# Altium schematic sheet FPGA_Config.SchDoc
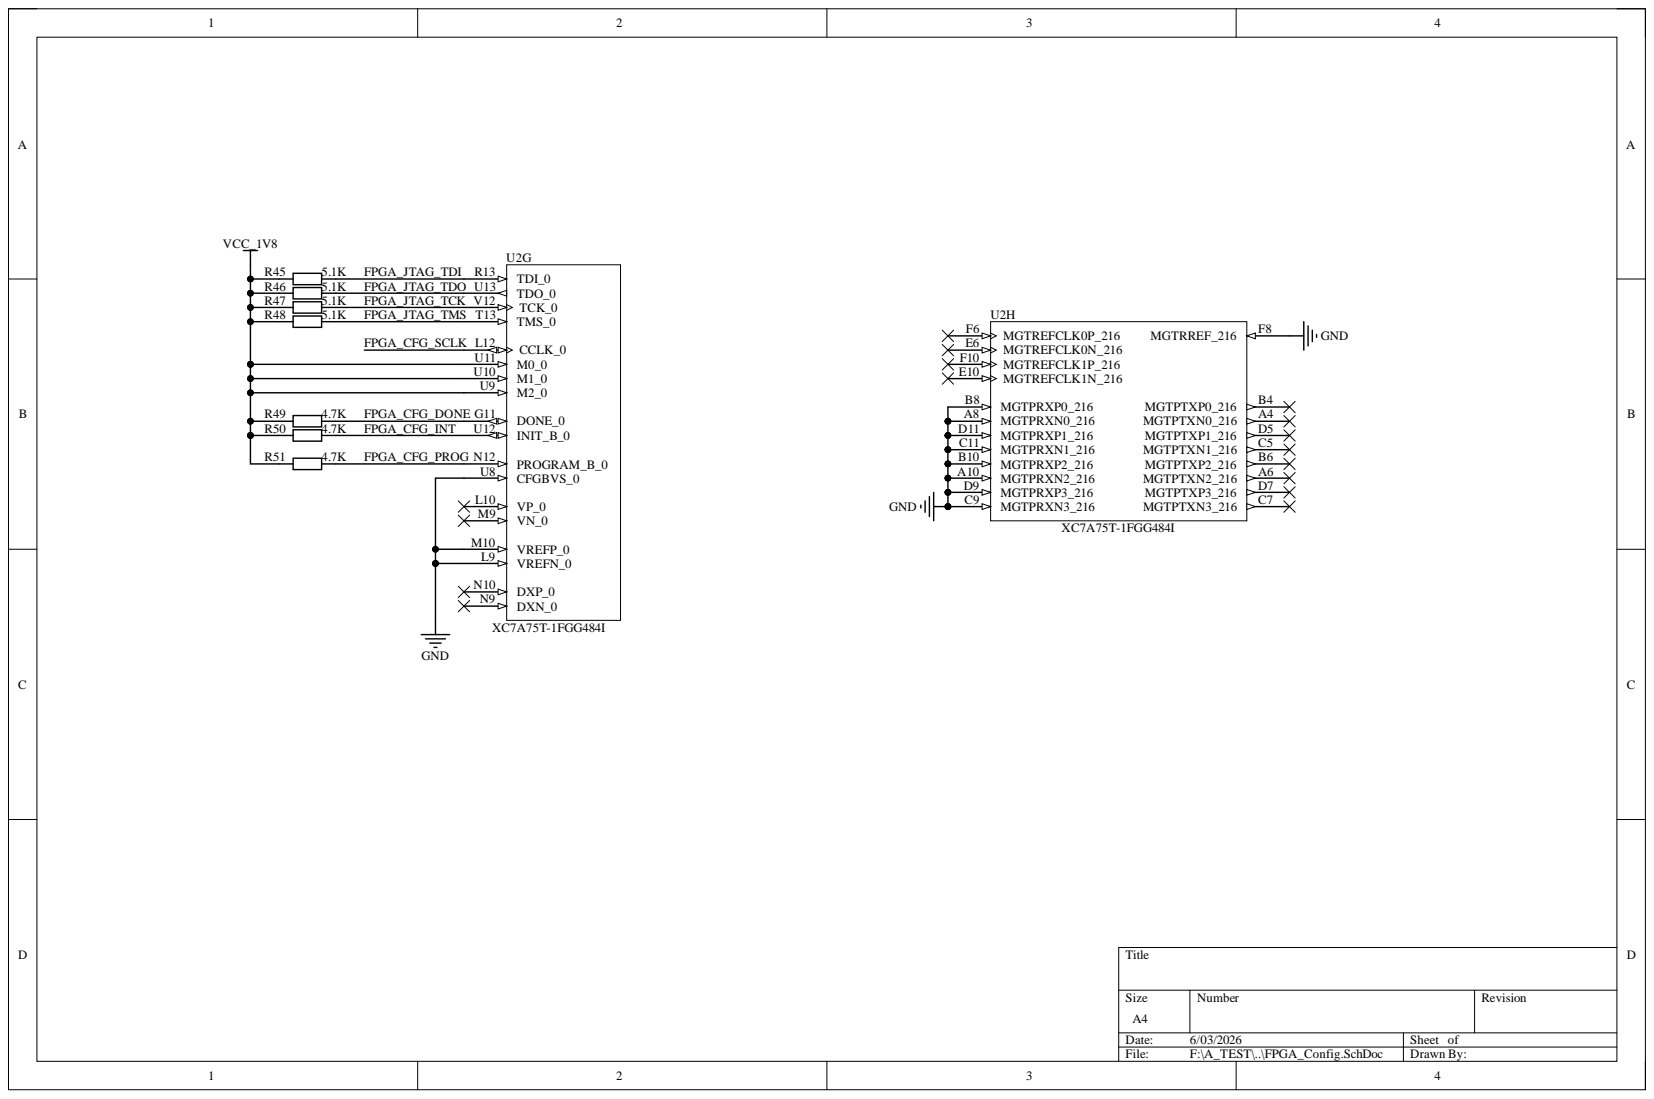
[diagram p0: FPGA_Config.SchDoc]

## Parts
R45  5.1K  [R 0402_L]
R46  5.1K  [R 0402_L]
R47  5.1K  [R 0402_L]
R48  5.1K  [R 0402_L]
R49  4.7K  [R 0402_L]
R50  4.7K  [R 0402_L]
R51  4.7K  [R 0402_L]
U2  XC7A75T-1FGG484I  [FGG484]  (parts G,H)

## Nets
GND: U2.A8(MGTPRXN0_216) U2.A10(MGTPRXN2_216) U2.B8(MGTPRXP0_216) U2.B10(MGTPRXP2_216) U2.C9(MGTPRXN3_216) U2.C11(MGTPRXN1_216) U2.D9(MGTPRXP3_216) U2.D11(MGTPRXP1_216) U2.F8(MGTRREF_216) U2.L9(VREFN_0) U2.M10(VREFP_0) U2.U8(CFGBVS_0)
VCC_1V8: R45.1 R46.1 R47.1 R48.1 R49.2 R50.2 R51.2 U2.U9(M2_0) U2.U10(M1_0) U2.U11(M0_0)
FPGA_CFG_DONE: R49.1 U2.G11(DONE_0)
FPGA_CFG_INT: R50.1 U2.U12(INIT_B_0)
FPGA_CFG_PROG: R51.1 U2.N12(PROGRAM_B_0)
FPGA_CFG_SCLK: U2.L12(CCLK_0)
FPGA_JTAG_TCK: R47.2 U2.V12(TCK_0)
FPGA_JTAG_TDI: R45.2 U2.R13(TDI_0)
FPGA_JTAG_TDO: R46.2 U2.U13(TDO_0)
FPGA_JTAG_TMS: R48.2 U2.T13(TMS_0)

Not connected: U2 A4(MGTPTXN0_216) A6(MGTPTXN2_216) B4(MGTPTXP0_216) B6(MGTPTXP2_216) C5(MGTPTXN1_216) C7(MGTPTXN3_216) D5(MGTPTXP1_216) D7(MGTPTXP3_216) E6(MGTREFCLK0N_216) E10(MGTREFCLK1N_216) F6(MGTREFCLK0P_216) F10(MGTREFCLK1P_216) L10(VP_0) M9(VN_0) N9(DXN_0) N10(DXP_0)

## Library data of the parts
R45-R51 = Res: 通用; Component Kind: Standard; Library Name: A_Schlib.SchLib
  R45-R48: value: 5.1K
  R49-R51: value: 4.7K
U2 = XC7A100T-1FGG484I: Artix-7 FPGA, 285 User I/Os, 4 GTP, 484-Ball BGA, Speed Grade 1, Industrial Grade, Pb-Free; Manufacturer: Xilinx; Block RAM Blocks (18Kb): 270; Block RAM Blocks (36Kb): 135; Block RAM Blocks (Max Kb): 4860; CLBs (Slices): 15850; Clock Mgmt Tiles (CMTs): 6; DSP48E1 Slices: 240; Logic Cells: 101440; Max User I/O: 300; Speed Grade: -1; CLBs (Max Distributed RAM Kb): 1188; Code_JEDEC: MS-034-AAJ-1; Component Kind: Standard; ComponentLink1Description: Datasheet; ComponentLink1URL: http://www.xilinx.com/support/documentation/data_sheets/ds180_7Series_Overview.pdf; ComponentLink2Description: Package Specification; ComponentLink2URL: http://www.xilinx.com/support/documentation/user_guides/ug475_7Series_Pkg_Pinout.pdf; ComponentLink3Description: DC and Switching Characteristics; ComponentLink3URL: http://www.xilinx.com/support/documentation/data_sheets/ds181_Artix_7_Data_Sheet.pdf; ComponentLink4Description: Package File; ComponentLink4URL: http://www.xilinx.com/support/packagefiles/a7packages/xc7a100tfgg484pkg.txt; ComponentLink5Description: Packaging and Pinout; ComponentLink5URL: http://www.xilinx.com/support/documentation/user_guides/ug475_7Series_Pkg_Pinout.pdf; DatasheetVersion: v1.12; Generic Part Number: XC7A100T; Library Name: Xilinx Artix-7.IntLib; Mounting Technology: Surface Mount; PackageDescription: Wire-Bond Fine-Pitch BGA, Body 23 x 23 mm, 1.0 mm Pitch; PackageReference: FGG484; PackageVersion: Rev 1.8; PartNumber: XC7A100T-1FGG484I; RoHS: Pb-Free
